# Altium schematic sheet PowerBoard_p1.SchDoc
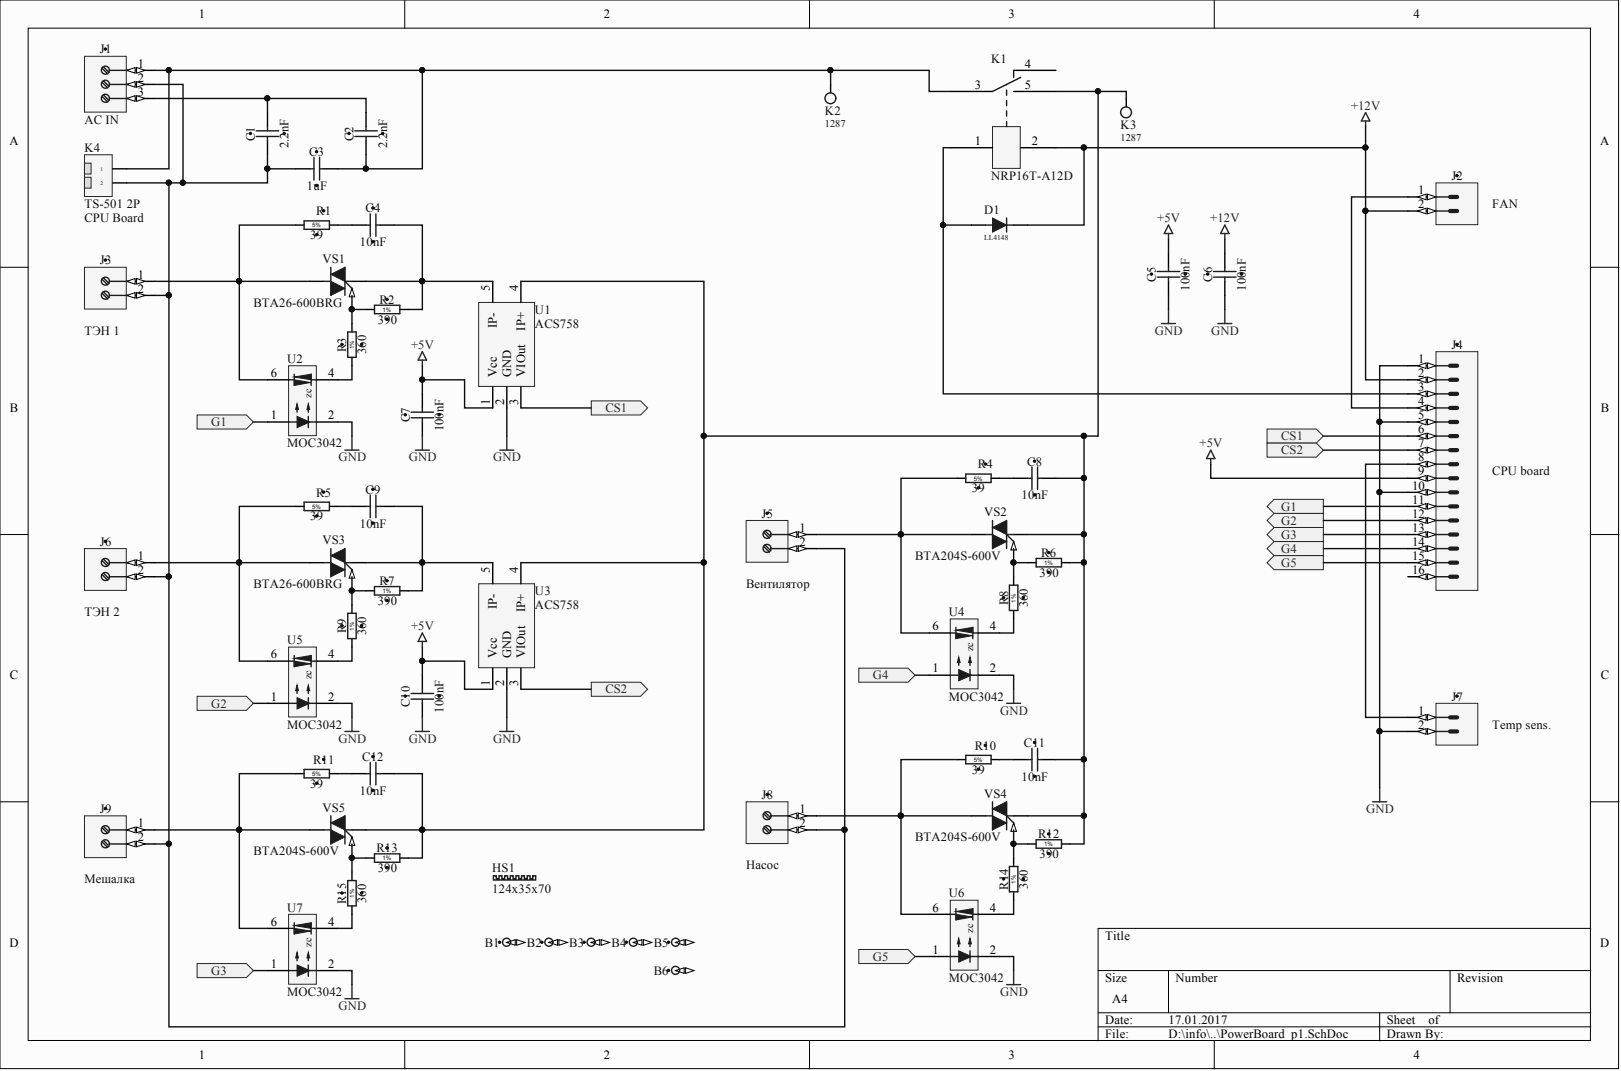
[diagram p0: PowerBoard_p1.SchDoc]

## Parts
B1  Plated Hole  [MTG350_650]  (value not drawn)  (no BOM)
B2  Plated Hole  [MTG350_650]  (value not drawn)  (no BOM)
B3  Plated Hole  [MTG350_650]  (value not drawn)  (no BOM)
B4  Plated Hole  [MTG350_650]  (value not drawn)  (no BOM)
B5  Plated Hole  [MTG350_650]  (value not drawn)  (no BOM)
B6  Plated Hole  [MTG350_650]  (value not drawn)  (no BOM)
C1  2.2nF  [CAPRB_MURATA_DHRE4AD222Z4QB]
C2  2.2nF  [CAPRB_MURATA_DHRE4AD222Z4QB]
C3  1uF  [CAPR2750-1300X3150X2400]
C4  10nF  [CAPR500-300X720X650]
C5  100nF  [CAPC2012X135N]
C6  100nF  [CAPC2012X135N]
C7  100nF  [CAPC2012X135N]
C8  10nF  [CAPR500-300X720X650]
C9  10nF  [CAPR500-300X720X650]
C10  100nF  [CAPC2012X135N]
C11  10nF  [CAPR500-300X720X650]
C12  10nF  [CAPR500-300X720X650]
D1  LL4148  [SOD80/MLL34]
HS1  124x35x70  [HeadSink 124x35x70]
J1  Family  [PHOENIX_1714968]  (value not drawn)
J2  KK®  [MOLEX_22-27-2021]  (value not drawn)
J3  Family  [PHOENIX_1715721]  (value not drawn)
J4  Header 16  [3M_N2516-6002RB]  (value not drawn)
J5  Family  [PHOENIX_1715721]  (value not drawn)
J6  Family  [PHOENIX_1715721]  (value not drawn)
J7  KK®  [MOLEX_22-27-2021]  (value not drawn)
J8  Family  [PHOENIX_1715721]  (value not drawn)
J9  Family  [PHOENIX_1715721]  (value not drawn)
K1  NRP16T-A12D  [NRP16T]
K2  1287  [Keystone 1287]
K3  1287  [Keystone 1287]
K4  TS-501 2P  [TS-501 2P-2.5]
R1  39  [RESADV508-1670X520]
R2  390  [RES1016-630X250]
R3  360  [RES1016-630X250]
R4  39  [RESADV508-1670X520]
R5  39  [RESADV508-1670X520]
R6  390  [RES1016-630X250]
R7  390  [RES1016-630X250]
R8  360  [RES1016-630X250]
R9  360  [RES1016-630X250]
R10  39  [RESADV508-1670X520]
R11  39  [RESADV508-1670X520]
R12  390  [RES1016-630X250]
R13  390  [RES1016-630X250]
R14  360  [RES1016-630X250]
R15  360  [RES1016-630X250]
U1  ACS758  [CB Package]
U2  MOC3042  [DIL6-300b]
U3  ACS758  [CB Package]
U4  MOC3042  [DIL6-300b]
U5  MOC3042  [DIL6-300b]
U6  MOC3042  [DIL6-300b]
U7  MOC3042  [DIL6-300b]
VS1  BTA26-600BRG  [TOP3-h34mm]
VS2  BTA204S-600V  [TO-252-3L (DPAK)]
VS3  BTA26-600BRG  [TOP3-h34mm]
VS4  BTA204S-600V  [TO-252-3L (DPAK)]
VS5  BTA204S-600V  [TO-252-3L (DPAK)]

## Ports
CS1, CS2, G1, G2, G3, G4, G5

## Nets
+5V: C5.2 C7.2 C10.2 J4.9 U1.1(Vcc) U3.1(Vcc)
+12V: C6.2 D1.2 J2.2 J4.2 K1.2
GND: C5.1 C6.1 C7.1 C10.1 J4.1 J4.5 J4.10 J7.2 U1.2(GND) U2.2 U3.2(GND) U4.2 U5.2 U6.2 U7.2
CS1: J4.6 U1.3(VIOut) ; port CS1
CS2: J4.7 U3.3(VIOut) ; port CS2
G1: J4.11 U2.1 ; port G1
G2: J4.12 U5.1 ; port G2
G3: J4.13 U7.1 ; port G3
G4: J4.14 U4.1 ; port G4
G5: J4.15 U6.1 ; port G5
NetC1_1: C1.1 C3.1 J1.2 J3.2 J5.2 J6.2 J8.2 J9.2 K4.2
NetC1_2: C1.2 C2.2 J1.3
NetC2_1: C2.1 C3.2 J1.1 K1.3 K2.1 K4.1
NetC4_1: C4.1 R1.2
NetC4_2: C4.2 R2.2 U1.5(IP-) VS1.1
NetC8_1: C8.1 R4.2
NetC8_2: C8.2 C11.2 C12.2 K1.5 K3.1 R6.2 R12.2 R13.2 U1.4(IP+) U3.4(IP+) VS2.1 VS4.1 VS5.1
NetC9_1: C9.1 R5.2
NetC9_2: C9.2 R7.2 U3.5(IP-) VS3.1
NetC11_1: C11.1 R10.2
NetC12_1: C12.1 R11.2
NetD1_1: D1.1 J4.3 K1.1
NetJ2_1: J2.1 J4.4
NetJ3_1: J3.1 R1.1 U2.6 VS1.2
NetJ4_8: J4.8 J7.1
NetJ5_1: J5.1 R4.1 U4.6 VS2.2
NetJ6_1: J6.1 R5.1 U5.6 VS3.2
NetJ8_1: J8.1 R10.1 U6.6 VS4.2
NetJ9_1: J9.1 R11.1 U7.6 VS5.2
NetR2_1: R2.1 R3.2 VS1.3
NetR3_1: R3.1 U2.4
NetR6_1: R6.1 R8.2 VS2.3
NetR7_1: R7.1 R9.2 VS3.3
NetR8_1: R8.1 U4.4
NetR9_1: R9.1 U5.4
NetR12_1: R12.1 R14.2 VS4.3
NetR13_1: R13.1 R15.2 VS5.3
NetR14_1: R14.1 U6.4
NetR15_1: R15.1 U7.4

Not connected: B1 1; B2 1; B3 1; B4 1; B5 1; B6 1; J4 16; K1 4

## Library data of the parts
B1-B6 = PLATED_HOLE3.5_PAD6.5: Single Terminal Socket; Part Description: Plated Through Hole: Hole Dia.=3.5mm Pad Dia.=6.5mm; Database Table Name: Pads; Mounted: No; ComponentHeight: 0mm; Component Type: Standard (No BOM); CreateDate: 20.11.2008; Family: Plated Hole; LatestRevisionDate: 23.11.2011; Library Name: Eletro-mechanical.DbLib; PackageDescription: Plated Through Hole: Hole Dia.=3.5mm Pad Dia.=6.5mm; Part Number: PLATED_HOLE3.5_PAD6.5; Pin Count: 1; SMD: No; Socket: No; Status: None; Table: Pads; Author: CERN DEM JLC
C1 C2 = CCR_2.2NF_7.5KV_+80%-20%_MURATA_DHRE4AD222Z4QB: Non-polarised capacitor; Manufacturer: MURATA; Part Description: High Voltage Ceramic Capacitor; Database Table Name: Capacitors THD; TC: Z5U; Voltage: 7.5kV; Case: LS9.5 W75 x D15; ComponentHeight: 20mm; Component Kind: Standard; Component Type: Standard; CreateDate: 26.09.2012; HelpURL: \\cern.ch\dfs\Applications\Altium\Datasheets\CCR_MURATA_DHRE4AD222Z4QB.pdf; LatestRevisionDate: 26.09.2012; Library Name: Capacitors.DbLib; Manufacturer Part Number: DHRE4AD222Z4QB; Mounted: Yes; Part Number: CCR_2.2NF_7.5KV_+80%-20%_MURATA_DHRE4AD222Z4QB; Pin Count: 2; SMD: No; Socket: No; Status: Obsolete; Table: Capacitors THD; Tolerance: +80%-20%; Value: 2.2nF; Author: CERN DEM JLC
C3 = CPPR_1UF_275VAC_20%_2750-1300X3150X2400: Non-polarised capacitor; Manufacturer: WIMA; Manufacturer Part Number: MKX21W41006D00MSSD; Part Description: Metallized Polypropylene RFI Capacitor Class X2 (MKP-X2); Database Table Name: Capacitors THD; Tolerance: ±20%; Voltage: 275VAC; Case: LS27.5 W13 x L31.5 x H24; ComponentHeight: 24mm; Component Kind: Standard; Component Type: Standard; CreateDate: 23.06.2008; HelpURL: \\cern.ch\dfs\Applications\Altium\Datasheets\CPPR_WIMA_MKP-X2.pdf; LatestRevisionDate: 30.01.2012; Library Name: Capacitors.DbLib; Mounted: Yes; Part Number: CPPR_1UF_275VAC_20%_2750-1300X3150X2400; Pin Count: 2; SMD: No; Socket: No; Status: None; Table: Capacitors THD; Value: 1uF; Author: CERN DEM JLC
C4 C8 C9 C11 C12 = CPER_10NF_400V_10%_500-300X720X650: Non-polarised capacitor; Manufacturer: EPCOS; Manufacturer Part Number: B32529C6103K000; Part Description: Metallized Polyester Film Capacitor (MKT); Database Table Name: Capacitors THD; Tolerance: ±10%; Voltage: 400VDC/200VAC; Case: LS5 W3 x L7.2 x H6.5; ComponentHeight: 6.5mm; Component Kind: Standard; Component Type: Standard; CreateDate: 23.03.2009; HelpURL: \\cern.ch\dfs\Applications\Altium\Datasheets\CPER_EPCOS_B32529.pdf; LatestRevisionDate: 23.03.2009; Library Name: Capacitors.DbLib; Mounted: Yes; Part Number: CPER_10NF_400V_10%_500-300X720X650; Pin Count: 2; SMD: No; Socket: No; Status: None; Table: Capacitors THD; Value: 10nF; Author: CERN DEM JLC
C5-C7 C10 = CC0805_100NF_50V_10%_X7R: Non-polarised capacitor; Manufacturer: GENERIC; Manufacturer1 Part Number: B37941K5104K62; Part Description: SMD Multilayer Chip Ceramic Capacitor; Database Table Name: Capacitors SMD; TC: X7R; Tolerance: ±10%; Voltage: 50V; Case: 0805; Component Kind: Standard; Component Type: Standard; CreateDate: 03.12.2007; HelpURL: \\cern.ch\dfs\Applications\Altium\Datasheets\CC0805_X7R_EPCOS.pdf; LatestRevisionDate: 03.12.2007; Library Name: Capacitors.DbLib; Manufacturer1 ComponentHeight: 1.35mm; Manufacturer1 Example: EPCOS; Manufacturer Part Number: CC0805_100NF_50V_10%_X7R; Mounted: Yes; Part Number: CC0805_100NF_50V_10%_X7R; Pin Count: 2; SMD: Yes; Socket: No; Status: Preferred; Table: Capacitors SMD; Value: 100nF; Author: CERN DEM JLC
D1 = LL4148: 75V 0.3A SOD80/MLL34; Component Kind: Standard; Library Name: Diodes.IntLib; Price: 0.006; Signal Integrity: D; Simulation: 1N4148
HS1 = HeadSink 124x35x70: INDEL A5724/7; Component Kind: Standard; Library Name: PowerLib.SchLib; Price: 5
J1 = PHOENIX_1714968: Terminal Block, 3 Positions, Screw Connection; Manufacturer: PHOENIX CONTACT; Manufacturer Part Number: 1714968 (MKDS 5/ 3-6,35); Part Description: 30A, 300V, Terminal Block, Pitch 6.35mm, 3 Positions, Screw Connection; ComponentHeight: 21.5mm; Component Kind: Standard; Component Type: Standard; CreateDate: 25.10.2011; Database Table Name: PHOENIX; HelpURL: \\cern.ch\dfs\Applications\Altium\Datasheets\PHOENIX_1714968.pdf; LatestRevisionDate: 25.10.2011; Library Name: Connectors.DbLib; Mounted: Yes; Part Number: PHOENIX_1714968; Pin Count: 3; SMD: No; Socket: No; Status: None; Table: PHOENIX; Author: CERN DEM JLC
J2 J7 = MOLEX_22-27-2021: Connector 2 Male; Part Description: 2.54mm (.100") Pitch KK® Wire-to-Board Header, Vertical, with Friction Lock, 2 Circuits, Tin (Sn) Plating; Database Table Name: MOLEX; ComponentHeight: 11.7mm; Component Kind: Standard; Component Type: Standard; CreateDate: 04.12.2008; Family: KK®; HelpURL: \\cern.ch\dfs\Applications\Altium\Datasheets\MOLEX_22-27-2021.pdf; LatestRevisionDate: 04.12.2008; Library Name: Connectors.DbLib; Manufacturer: MOLEX; Manufacturer Part Number: 22-27-2021; Mounted: Yes; Part Number: MOLEX_22-27-2021; Pin Count: 2; SMD: No; Socket: No; Status: None; Table: MOLEX; Author: CERN DEM JLC
J3 J5 J6 J8 J9 = PHOENIX_1715721: Terminal Block, 2 Positions, Screw Connection; Manufacturer: PHOENIX CONTACT; Manufacturer Part Number: 1715721 (MKDS 1,5/2-5,08); Part Description: 17.5A, 400V, Terminal Block, Pitch 5.08mm, 2 Positions, Screw Connection; ComponentHeight: 13.8mm; Component Kind: Standard; Component Type: Standard; CreateDate: 06.01.2010; Database Table Name: PHOENIX; HelpURL: \\cern.ch\dfs\Applications\Altium\Datasheets\PHOENIX_1715721.pdf; LatestRevisionDate: 25.02.2013; Library Name: Connectors.DbLib; Mounted: Yes; Part Number: PHOENIX_1715721; Pin Count: 2; SMD: No; Socket: No; Status: None; Table: PHOENIX; Author: CERN DEM JLC
J4 = 3M_N2516-6002RB: Connector 16 Male; Part Description: 16 Contacts - Straight .100'' x .100'' Low Profile Four-Wall Header, 2500 Series; Database Table Name: 3M; ComponentHeight: 10.03mm; Component Kind: Standard; Component Type: Standard; CreateDate: 11.02.2009; Family: Header 16; HelpURL: \\cern.ch\dfs\Applications\Altium\Datasheets\3M_N2516-6002RB.pdf; LatestRevisionDate: 11.08.2009; Library Name: Connectors.DbLib; Manufacturer: 3M; Manufacturer Part Number: N2516-6002RB; Mounted: Yes; Part Number: 3M_N2516-6002RB; Pin Count: 16; SMD: No; Socket: No; Status: None; Table: 3M; Author: CERN DEM JLC
K1 = Relay NRP16T-A12D: Component Kind: Standard; Description: NRP16T-A12D; Library Name: PowerLib.SchLib
K2 K3 = Keystone 1287: Component Kind: Standard; Library Name: Connektor.IntLib; Price: 0.01
K4 = TS-501 2P: 10A/300V AC 5mm; Component Kind: Standard; Library Name: Connektor.IntLib; Price: 0.27
R1 R4 R5 R10 R11 = RMF_39R_5%_3W_250PPM_508-1670X520_v: Resistor - 5%; Manufacturer: GENERIC; Manufacturer1 Part Number: PR03000203909JAC00; Part Description: Leaded Power Metal Film Resistor (Vertical Mount) PR03 Series; Database Table Name: Resistors THD; Power: 3W; TC: ±250ppm/°C; Case: LS5.08 L16.7 x D5.2; ComponentHeight: 23.6mm; Component Kind: Standard; Component Type: Standard; CreateDate: 29.10.2012; HelpURL: \\cern.ch\dfs\Applications\Altium\Datasheets\RMF_VISHAY_PR03.pdf; LatestRevisionDate: 29.10.2012; Library Name: Resistors.DbLib; Manufacturer1 ComponentHeight: 23.6mm; Manufacturer1 Example: VISHAY BCCOMPONENT; Manufacturer Part Number: RMF_39R_5%_3W_250PPM_508-1670X520; Mounted: Yes; Part Number: RMF_39R_5%_3W_250PPM_508-1670X520_v; Pin Count: 2; SMD: No; Socket: No; Status: Preferred; Table: Resistors THD; Tolerance: ±5%; Value: 39; Author: CERN DEM JLC
R2 R6 R7 R12 R13 = RMF_390R_1%_0.6W_50PPM_1016-630X250: Resistor - 1%; Manufacturer: GENERIC; Manufacturer1 Part Number: MBB02070C3900FCT00; Part Description: Leaded Metal Film Resistor; Database Table Name: Resistors THD; Power: 0.6W; TC: ±50ppm/°C; Case: LS10.16 L6.3 x D2.5; ComponentHeight: 2.5mm; Component Kind: Standard; Component Type: Standard; CreateDate: 04.12.2007; HelpURL: \\cern.ch\dfs\Applications\Altium\Datasheets\RMF_VISHAY_MBB0207.pdf; LatestRevisionDate: 07.04.2008; Library Name: Resistors.DbLib; Manufacturer1 ComponentHeight: 2.5mm; Manufacturer1 Example: VISHAY BEYSCHLAG; Manufacturer Part Number: RMF_390R_1%_0.6W_50PPM_1016-630X250; Mounted: Yes; Part Number: RMF_390R_1%_0.6W_50PPM_1016-630X250; Pin Count: 2; SMD: No; Socket: No; Status: Preferred; Table: Resistors THD; Tolerance: ±1%; Value: 390; Author: CERN DEM JLC
R3 R8 R9 R14 R15 = RMF_360R_1%_0.6W_50PPM_1016-630X250: Resistor - 1%; Manufacturer: GENERIC; Manufacturer1 Part Number: MBB02070C3600FCT00; Part Description: Leaded Metal Film Resistor; Database Table Name: Resistors THD; Power: 0.6W; TC: ±50ppm/°C; Case: LS10.16 L6.3 x D2.5; ComponentHeight: 2.5mm; Component Kind: Standard; Component Type: Standard; CreateDate: 04.12.2007; HelpURL: \\cern.ch\dfs\Applications\Altium\Datasheets\RMF_VISHAY_MBB0207.pdf; LatestRevisionDate: 07.04.2008; Library Name: Resistors.DbLib; Manufacturer1 ComponentHeight: 2.5mm; Manufacturer1 Example: VISHAY BEYSCHLAG; Manufacturer Part Number: RMF_360R_1%_0.6W_50PPM_1016-630X250; Mounted: Yes; Part Number: RMF_360R_1%_0.6W_50PPM_1016-630X250; Pin Count: 2; SMD: No; Socket: No; Status: Preferred; Table: Resistors THD; Tolerance: ±1%; Value: 360; Author: CERN DEM JLC
U1 U3 = ACS758: Component Kind: Standard; Description: ACS758; Library Name: PowerLib.SchLib
U2 U4-U7 = MOC3042: Component Kind: Standard; Description: MOC3042; Library Name: PowerLib.SchLib
VS1 VS3 = BTA26-600BRG: Component Kind: Standard; Description: BTA26-600BRG; Library Name: PowerLib.SchLib
VS2 VS4 VS5 = BTA204S-600V: Component Kind: Standard; Description: BTA204S-600V; Library Name: PowerLib.SchLib
